# Altium schematic sheet ESP8266.SchDoc(ESP8266)
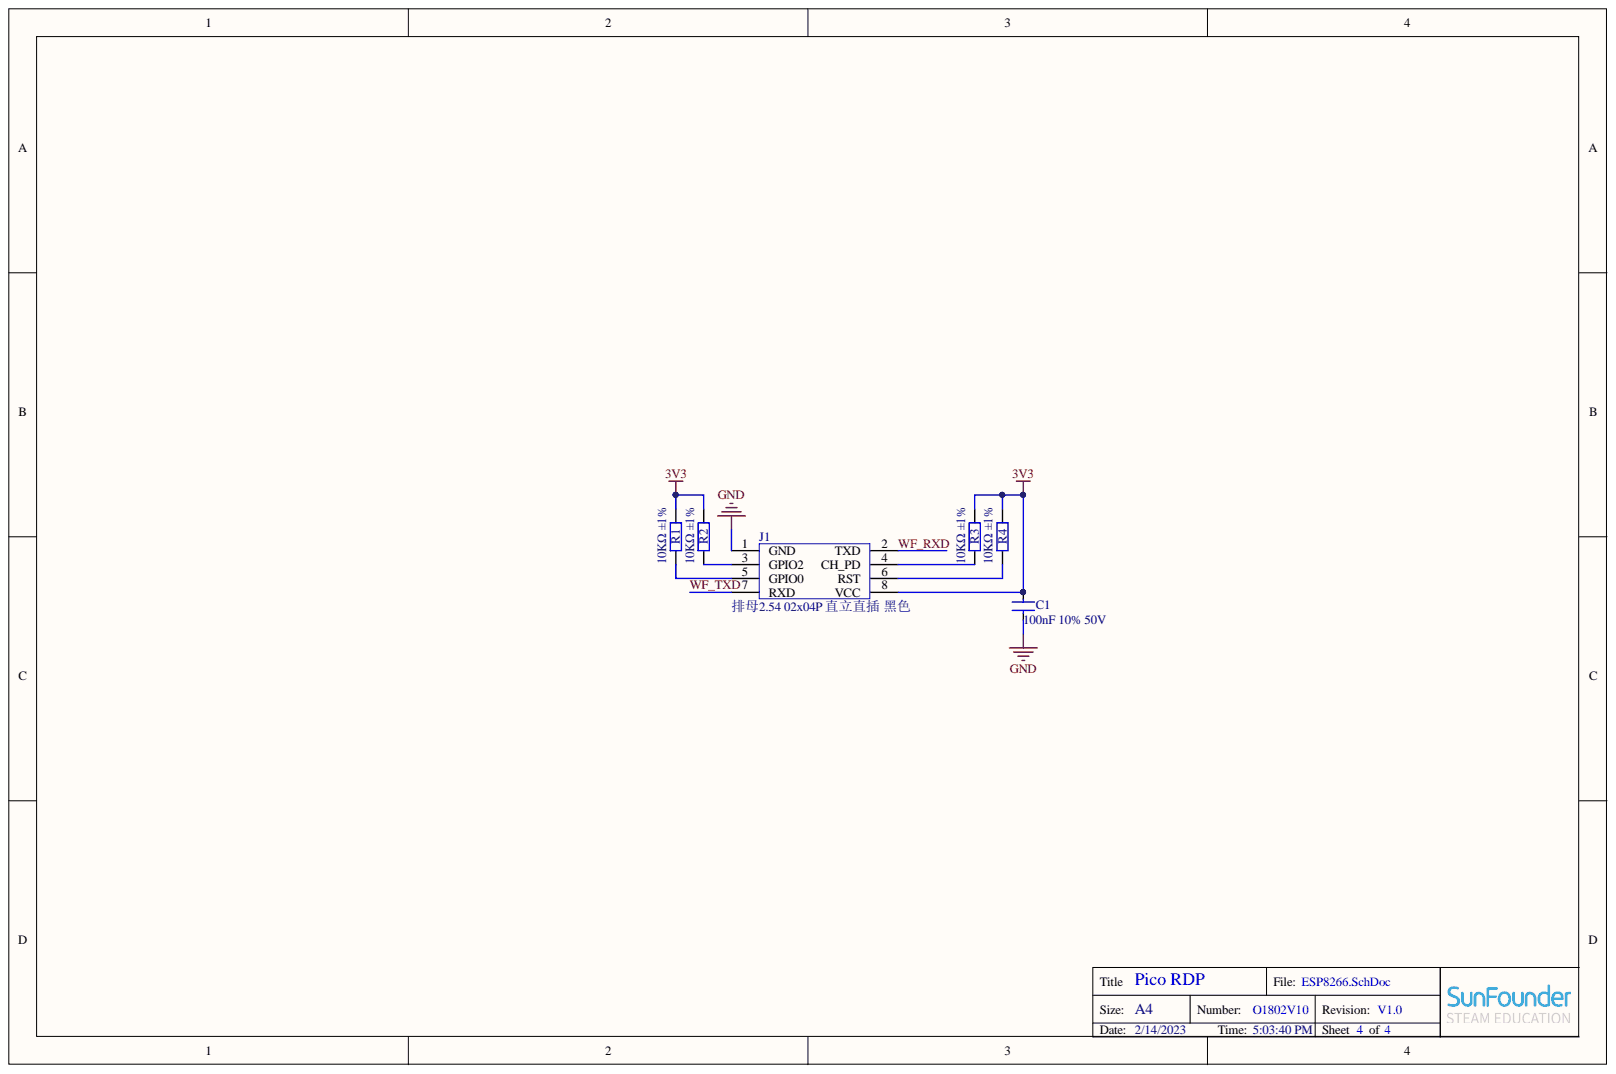
[diagram p0: ESP8266.SchDoc]

## Parts
C1  100nF 10% 50V  [电容-0603]
J1  排母2.54 02x04P 直立直插 黑色  [ESP01]
R1  10KΩ ±1%  [电阻_0603]
R2  10KΩ ±1%  [电阻_0603]
R3  10KΩ ±1%  [电阻_0603]
R4  10KΩ ±1%  [电阻_0603]

## Nets
3V3: C1.2 J1.8(VCC) R1.1 R2.1 R3.1 R4.1
GND: C1.1 J1.1(GND)
WF_RXD: J1.2(TXD)
WF_TXD: J1.7(RXD)
NetJ1_3: J1.3(GPIO2) R2.2
NetJ1_4: J1.4(CH_PD) R3.2
NetJ1_5: J1.5(GPIO0) R1.2
NetJ1_6: J1.6(RST) R4.2

## Library data of the parts
C1 = Cap: 无极性贴片电容; Component Kind: Standard; Library Name: SunFounder_Library.IntLib
J1 = ESP01: Component Kind: Standard; Library Name: Schlib1.SchLib
R1-R4 = R: 贴片电阻; 版权归属: 源创客; BOM名称: 贴片电阻; Component Kind: Standard; Library Name: ESP32 HAT.SchLib; 学习资源: www.ooocke.com
